# Altium schematic sheet AnalogParts.SchDoc(AnalogParts)
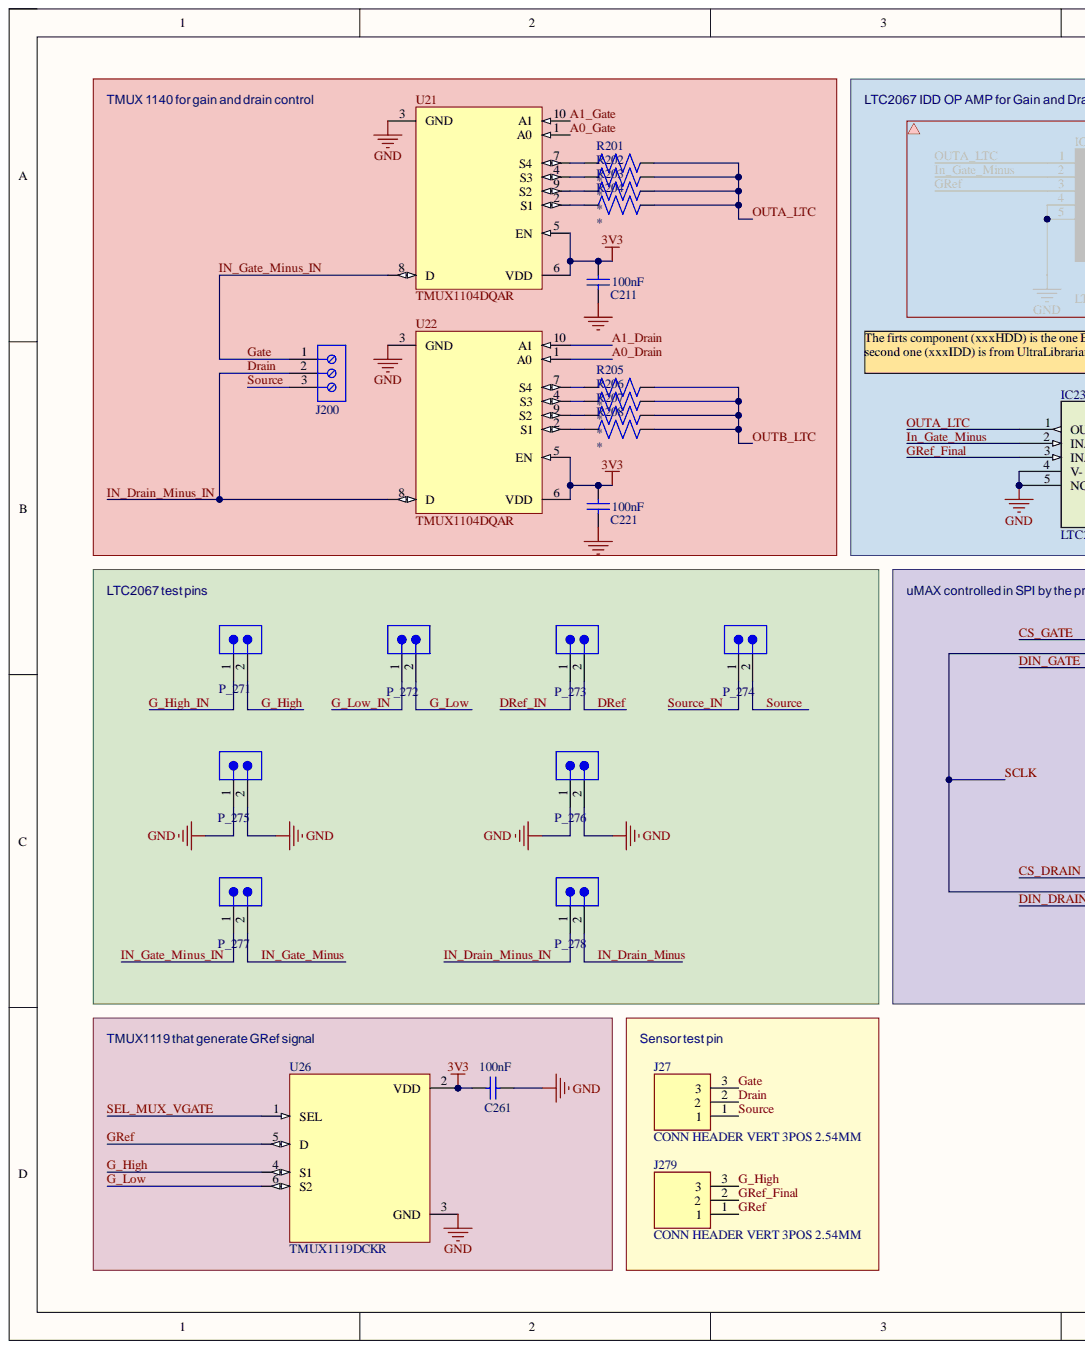
[diagram p0: AnalogParts.SchDoc, left]
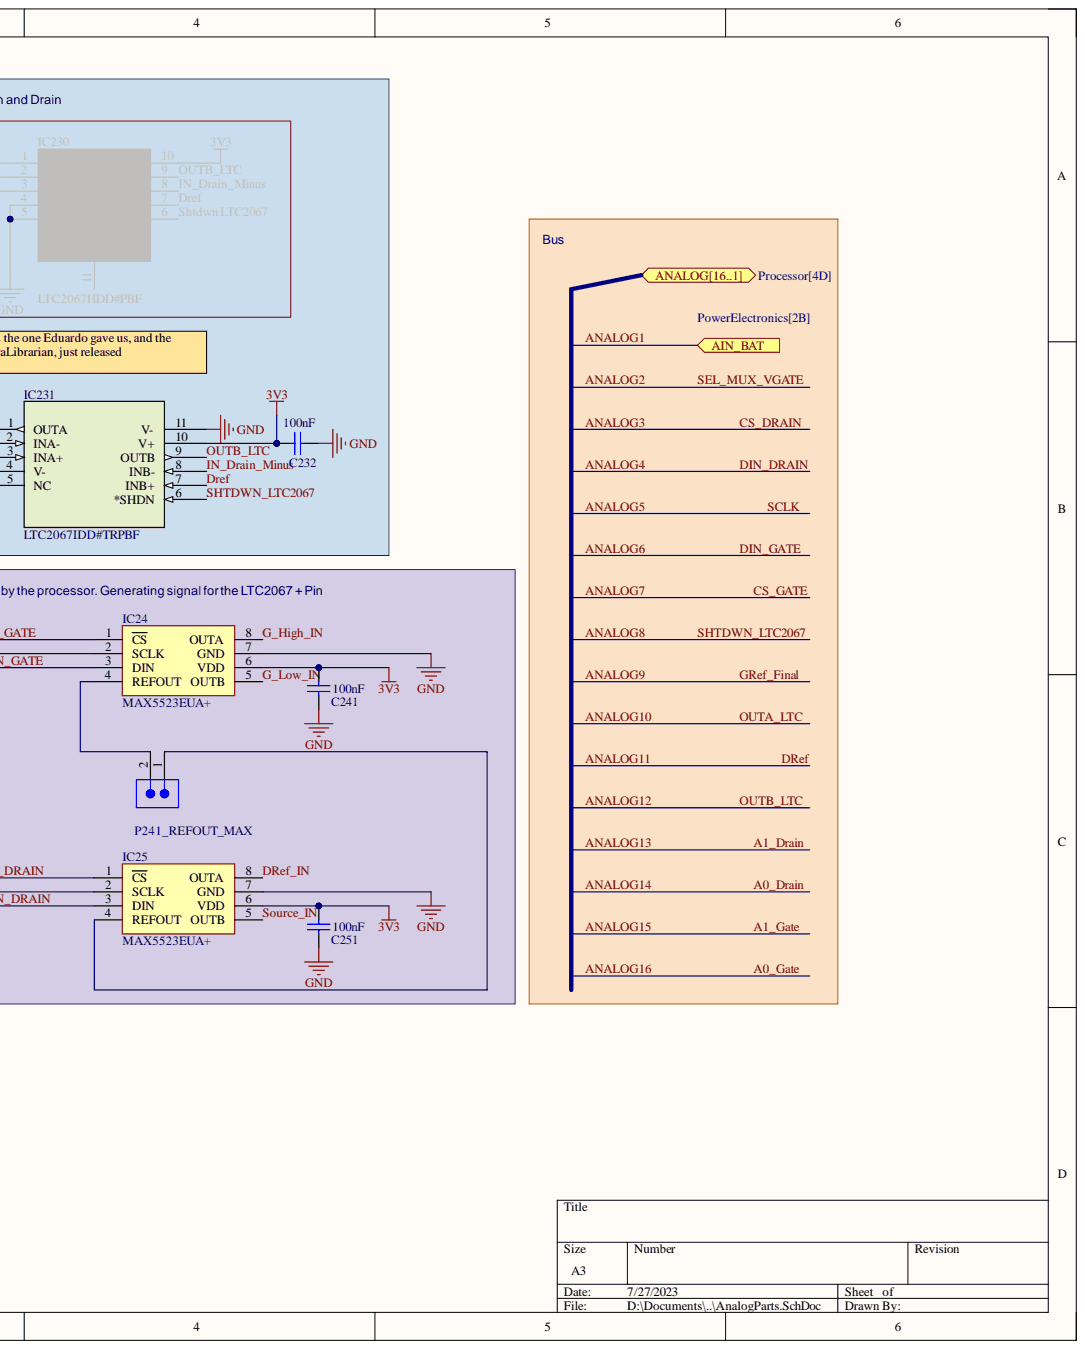
[diagram p1: AnalogParts.SchDoc, right]

## Parts
C211  100nF  [CAPC1608X06L]
C221  100nF  [CAPC1608X06L]
C232  100nF  [CAPC1608X06L]
C241  100nF  [CAPC1608X06L]
C251  100nF  [CAPC1608X06L]
C261  100nF  [CAPC1608X06L]
IC24  MAX5523EUA+  [MAX5523EUA+]
IC25  MAX5523EUA+  [MAX5523EUA+]
IC231  LTC2067IDD#TRPBF  [05-08-1699_ADI]
J27  CONN HEADER VERT 3POS 2.54MM  [CONN HEADER VERT 3POS 2.54MM]
J200  691210910003  [CONN_3P_691210910003]  (value not drawn)
J279  CONN HEADER VERT 3POS 2.54MM  [CONN HEADER VERT 3POS 2.54MM]
P241_REFOUT_MAX  Pin header 2p  [Pin_header_1x2]  (value not drawn)
P_271  Pin header 2p  [Pin_header_1x2]  (value not drawn)
P_272  Pin header 2p  [Pin_header_1x2]  (value not drawn)
P_273  Pin header 2p  [Pin_header_1x2]  (value not drawn)
P_274  Pin header 2p  [Pin_header_1x2]  (value not drawn)
P_275  Pin header 2p  [Pin_header_1x2]  (value not drawn)
P_276  Pin header 2p  [Pin_header_1x2]  (value not drawn)
P_277  Pin header 2p  [Pin_header_1x2]  (value not drawn)
P_278  Pin header 2p  [Pin_header_1x2]  (value not drawn)
R201  (no value)  [RESC1608X04.5L]
R202  (no value)  [RESC1608X04.5L]
R203  (no value)  [RESC1608X04.5L]
R204  (no value)  [RESC1608X04.5L]
R205  (no value)  [RESC1608X04.5L]
R206  (no value)  [RESC1608X04.5L]
R207  (no value)  [RESC1608X04.5L]
R208  (no value)  [RESC1608X04.5L]
U21  TMUX1104DQAR  [TMUX1104DQAR]
U22  TMUX1104DQAR  [TMUX1104DQAR]
U26  TMUX1119DCKR  [SC70_200X125_6N]

## Ports
AIN_BAT, ANALOG1, ANALOG2, ANALOG3, ANALOG4, ANALOG5, ANALOG6, ANALOG7, ANALOG8, ANALOG9, ANALOG10, ANALOG11, ANALOG12, ANALOG13, ANALOG14, ANALOG15, ANALOG16, ANALOG[16..1]

## Nets
3V3: C211.1 C221.1 C232.1 C241.1 C251.1 C261.1 IC24.6(VDD) IC25.6(VDD) IC231.10(V+) U21.5(EN) U21.6(VDD) U22.5(EN) U22.6(VDD) U26.2(VDD)
GND: C211.2 C221.2 C232.2 C241.2 C251.2 C261.2 IC24.7(GND) IC25.7(GND) IC231.4(V-) IC231.5(NC) IC231.11(V-) P_275.1 P_275.2 P_276.1 P_276.2 U21.3(GND) U22.3(GND) U26.3(GND)
A0_Drain: U22.1(A0) ; port ANALOG[16..1]  [also labelled ]
A0_Gate: U21.1(A0) ; port ANALOG[16..1]  [also labelled ]
A1_Drain: U22.10(A1) ; port ANALOG[16..1]  [also labelled ]
A1_Gate: U21.10(A1) ; port ANALOG[16..1]  [also labelled ]
ANALOG2: U26.1(SEL) ; port ANALOG[16..1]  [also labelled ]
ANALOG3: IC25.1(CS) ; port ANALOG[16..1]  [also labelled ]
ANALOG4: IC25.3(DIN) ; port ANALOG[16..1]  [also labelled ]
ANALOG5: IC24.2(SCLK) IC25.2(SCLK) ; port ANALOG[16..1]  [also labelled ]
ANALOG6: IC24.3(DIN) ; port ANALOG[16..1]  [also labelled ]
ANALOG7: IC24.1(CS) ; port ANALOG[16..1]  [also labelled ]
ANALOG8: IC231.6(*SHDN) ; port ANALOG[16..1]  [also labelled ]
ANALOG9: IC231.3(INA+) J279.2 ; port ANALOG[16..1]  [also labelled ]
ANALOG10: IC231.1(OUTA) R201.2 R202.2 R203.2 R204.2 ; port ANALOG[16..1]  [also labelled ]
ANALOG11: IC231.7(INB+) P_273.2 ; port ANALOG[16..1]  [also labelled ]
ANALOG12: IC231.9(OUTB) R205.2 R206.2 R207.2 R208.2 ; port ANALOG[16..1]  [also labelled ]
Drain: J27.2 J200.2 P_278.1 U22.8(D)
DRef_IN: IC25.8(OUTA) P_273.1
G_High: J279.3 P_271.2 U26.4(S1)
G_High_IN: IC24.8(OUTA) P_271.1
G_Low: P_272.2 U26.6(S2)
G_Low_IN: IC24.5(OUTB) P_272.1
Gate: J27.3 J200.1 P_277.1 U21.8(D)
GRef: J279.1 U26.5(D)
IN_Drain_Minus: IC231.8(INB-) P_278.2
In_Gate_Minus: IC231.2(INA-) P_277.2
Source: J27.1 J200.3 P_274.2
Source_IN: IC25.5(OUTB) P_274.1
NetIC24_4: IC24.4(REFOUT) P241_REFOUT_MAX.2
NetIC25_4: IC25.4(REFOUT) P241_REFOUT_MAX.1
NetR201_1: R201.1 U21.7(S4)
NetR202_1: R202.1 U21.4(S3)
NetR203_1: R203.1 U21.9(S2)
NetR204_1: R204.1 U21.2(S1)
NetR205_1: R205.1 U22.7(S4)
NetR206_1: R206.1 U22.4(S3)
NetR207_1: R207.1 U22.9(S2)
NetR208_1: R208.1 U22.2(S1)
ANALOG1: ; port AIN_BAT, port ANALOG[16..1]  [also labelled ]

## Library data of the parts
C211 C221 C232 C241 C251 C261 = C0603-4µ7: Capacitor, X5R, ±10%; Value: 4.7µF; Component Kind: Standard; Library Name: ProgettoAgrifoodLibreriaSCH.SchLib
IC24 IC25 = MAX5523EUA+: Integrated Circuit; Manufacturer_Name: Analog Devices; Component Kind: Standard; Datasheet Link: https://pdfserv.maximintegrated.com/en/ds/MAX5522-MAX5525.pdf; Height: 1.1mm; Manufacturer_Part_Number: MAX5523EUA+; Mouser Part Number: 700-MAX5523EUA; Mouser Price/Stock: https://www.mouser.co.uk/ProductDetail/Analog-Devices-Maxim-Integrated/MAX5523EUA%2b?qs=9Cj2FLRihcNj5G7tv6WL1A%3D%3D; Library Name: LibreriaCircuitoInterfac_SCH.SchLib
IC231 = LTC2067IDD#TRPBF: No Description Available; Mfr_Name: Analog Devices Inc; Component Kind: Standard; RefDes: RefDes; Type: Linear; Library Name: LTC2067IDD&hash_TRPBF.SchLib
J27 J279 = CONN HEADER VERT 3POS 2.54MM: Connector; Manufacturer_Name: Wurth Elektronik; Manufacturer_Part_Number: 61300311121; Component Kind: Standard; Datasheet Link: http://katalog.we-online.de/em/datasheet/6130xx11121.pdf; Height: 8.69mm; Library Name: add.SchLib; Mouser Part Number: 710-61300311121; Mouser Price/Stock: https://www.mouser.co.uk/ProductDetail/Wurth-Elektronik/61300311121?qs=PhR8RmCirEYxRWFJzNKsUw%3D%3D
J200 = CONN_3P_691210910003: WR-TBL Series 2109 Horizontal Entry, THT, pitch 2.54mm, 3p; Manufacturer: Wurth Elektronik; Modularity: No; Rated Current: 6A; Working Voltage: 150V; Application: Rising Cage Clamp; Category: Terminal Blocks; Component Kind: Standard; ComponentLink1Description: Website Link; ComponentLink1URL: https://www.we-online.com/redexpert/article/691210910003?ad; ComponentLink2Description: Datasheet Link; ComponentLink2URL: https://www.we-online.com/redexpert/spec/691210910003?ad; Library Name: add.SchLib; Manufacturer Part Number: 691210910003; Match Code: WR-TBL; Mount: Through Hole; Number of Pins: 3; Number of Rows: 1; Operating Temperature Max: 105°C; Operating Temperature Min: -40°C; Orientation: Horizontal; Packaging: Box; Pitch: 2.54mm
P241_REFOUT_MAX P_271-P_278 = Pin header 2p: Pin Header, THT, Vertical, pitch 2.54mm, 1 Row, 2P; Component Kind: Standard; Value: Pin header 2p; Library Name: ProgettoAgrifoodLibreriaSCH.SchLib
R201-R208 = R0603: Resistor, ±1%, 0.063W; Component Kind: Standard; Library Name: ProgettoAgrifoodLibreriaSCH.SchLib
U21 U22 = TMUX1104DQAR: Multiplexer 4:1, 3-pA on-state leakage current, 1.08v - 5V supply range; Component Kind: Standard; Value: TMUX1104DQAR; Library Name: ProgettoAgrifoodLibreriaSCH.SchLib
U26 = TMUX1119DCKR: 2:1 precision switch, low leakage current, 1.08v - 5V supply range; MF: Texas Instruments; Availability: Unavailable; Component Kind: Standard; MP: TMUX1119DCKR; Package: SOT-SC70-6 Texas Instruments; Price: None; SnapEDA_Link: https://www.snapeda.com/parts/TMUX1119DCKR/Texas%20Instruments/view-part/3222194/?ref=snap; Texas_Instruments-Purchase-URL: https://snapeda.com/shop?store=Texas+Instruments&id=3222194; Value: TMUX1119DCKR; Library Name: ProgettoAgrifoodLibreriaSCH.SchLib
